# Altium schematic sheet wiced-ez-eval-core.SchDoc(wiced-ez-eval-core)
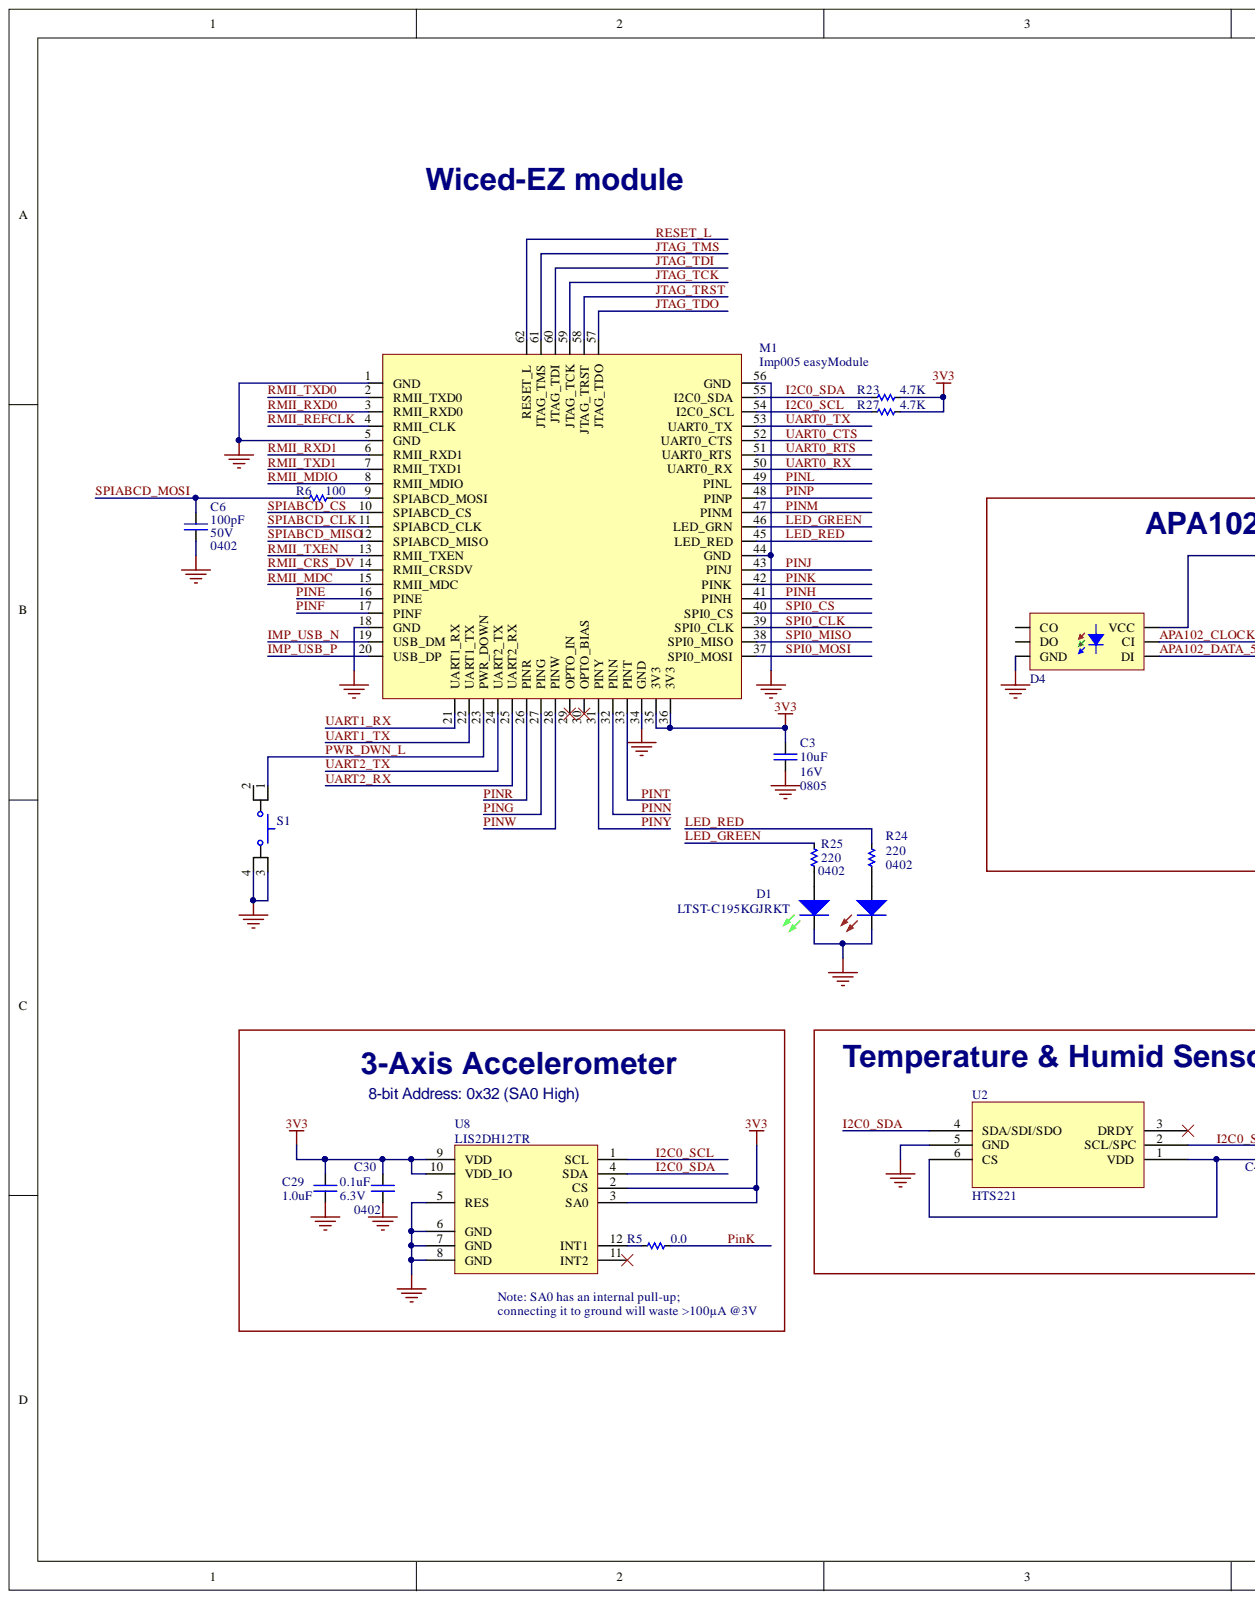
[diagram p0: wiced-ez-eval-core.SchDoc, left]
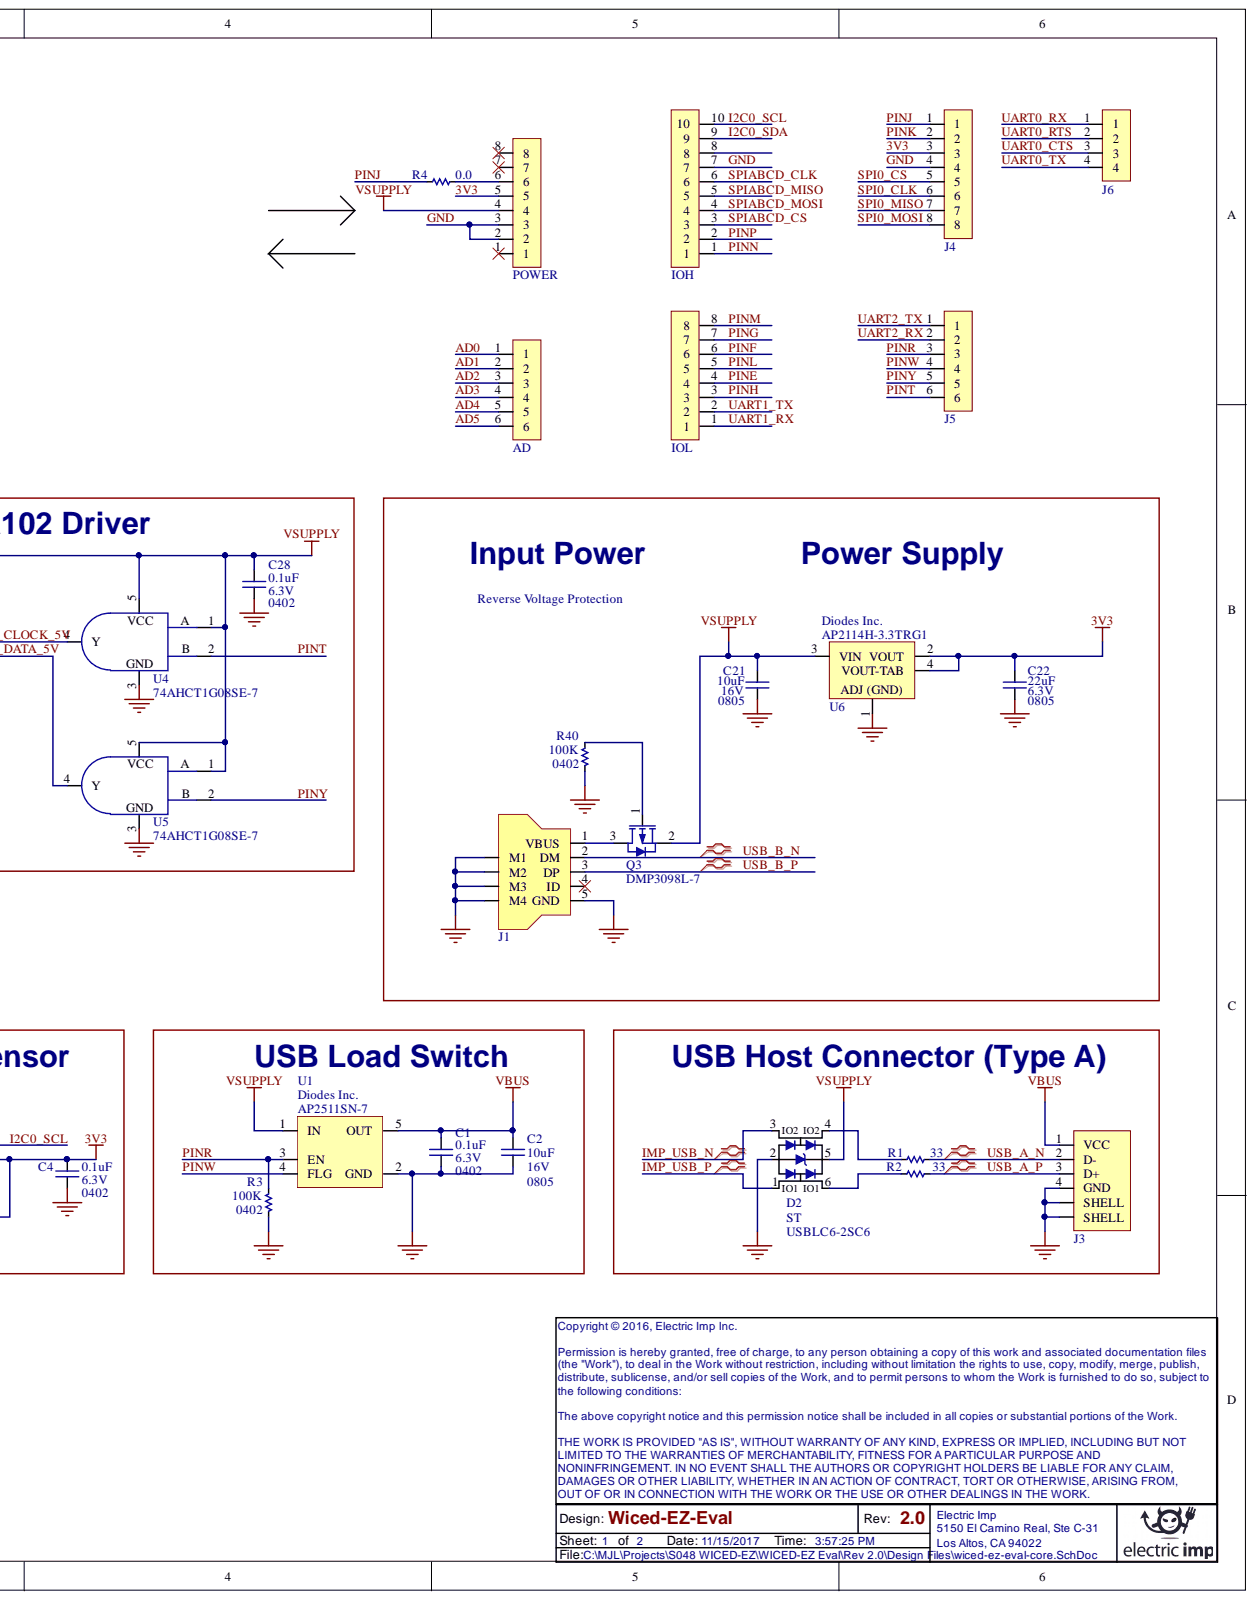
[diagram p1: wiced-ez-eval-core.SchDoc, right]

## Parts
AD  Header  [SKT254P1X6-N]  (value not drawn)
C1  value 0.1uF  Voltage: 6.3V  Package: 0402  [CAPC1005X07N]  (hidden comment: Ceramic Capacitor)
C2  value 10uF  Voltage: 16V  Package: 0805  [CAPC2012X14N]  (hidden comment: Ceramic Capacitor)
C3  value 10uF  Voltage: 16V  Package: 0805  [CAPC2012X14N]  (hidden comment: Ceramic Capacitor)
C4  value 0.1uF  Voltage: 6.3V  Package: 0402  [CAPC1005X07N]  (hidden comment: Ceramic Capacitor)
C6  value 100pF  Voltage: 50V  Package: 0402  [CAPC1005X07N]  (hidden comment: Ceramic Capacitor)
C21  value 10uF  Voltage: 16V  Package: 0805  [CAPC2012X14N]  (hidden comment: Ceramic Capacitor)
C22  value 22uF  Voltage: 6.3V  Package: 0805  [CAPC2012X14N]  (hidden comment: Ceramic Capacitor)
C28  value 0.1uF  Voltage: 6.3V  Package: 0402  [CAPC1005X07N]  (hidden comment: Ceramic Capacitor)
C29  value 1.0uF  [CAPC1005X07N]  (hidden comment: Ceramic Capacitor)
C30  value 0.1uF  Voltage: 6.3V  Package: 0402  [CAPC1005X07N]  (hidden comment: Ceramic Capacitor)
D1  Manufacturer Part Number: LTST-C195KGJRKT  [LED1616X07N-REDGREEN]  (hidden comment: LED Red and Green)
D2  Manufacturer Part Number: USBLC6-2SC6  Manufacturer: ST  [SOT95P300X130-6N]  (hidden comment: USB ESD Array)
D4  APA102C  [APA102C]
IOH  Header  [SKT254P1X10-N]  (value not drawn)
IOL  Header  [SKT254P1X8-N]  (value not drawn)
J1  USB Mini/Micro-B  [DX4R105JJCR1800]  (value not drawn)
J3  USB A Socket  [MOL482040001]  (value not drawn)
J4  Header  [SKT254P1X8-N]  (value not drawn)  (no BOM)
J5  Header  [SKT254P1X6-N]  (value not drawn)  (no BOM)
J6  Header  [HDR254P1X4-N]  (value not drawn)  (no BOM)
M1  Imp005 easyModule  [IMP005_EASYMODULE]
POWER  Header  [SKT254P1X8-N]  (value not drawn)
Q3  Manufacturer Part Number: DMP3098L-7  [SOT95P300X130-3N]  (hidden comment: PFET)
R1  value 33  [RESC1005X04N]  (hidden comment: Resistor)
R2  value 33  [RESC1005X04N]  (hidden comment: Resistor)
R3  value 100K  Package: 0402  [RESC1005X04N]  (hidden comment: Resistor)
R4  value 0.0  [RESC1005X04N]  (hidden comment: Resistor)
R5  value 0.0  [RESC1005X04N]  (hidden comment: Resistor)
R6  value 100  [RESC1005X04N]  (hidden comment: Resistor)
R23  value 4.7K  [RESC1005X04N]  (hidden comment: Resistor)
R24  value 220  [RESC1005X04N]  (hidden comment: Resistor)
R25  value 220  Package: 0402  [RESC1005X04N]  (hidden comment: Resistor)
R27  value 4.7K  [RESC1005X04N]  (hidden comment: Resistor)
R40  value 100K  Package: 0402  [RESC1005X04N]  (hidden comment: Resistor)
S1  Push Button  [BTN470X350X210-4N]  (value not drawn)
U1  AP2511SN  Manufacturer Part Number: AP2511SN-7  Manufacturer: Diodes Inc.  [QFN65P201X201X63_HS-7N]
U2  HTS221  [HLGA_6L]
U4  Manufacturer Part Number: 74AHCT1G08SE-7  [SOT65P200X125-5N]  (hidden comment: AND)
U5  Manufacturer Part Number: 74AHCT1G08SE-7  [SOT65P200X125-5N]  (hidden comment: AND)
U6  Manufacturer Part Number: AP2114H-3.3TRG1  Manufacturer: Diodes Inc.  [SOT230P700X180-4N]  (hidden comment: AP1117)
U8  LIS2DH12TR  [LGA50P200X200X100-12N-ST]

## Nets
VBUS: C1.2 C2.2 J3.1(VCC) U1.5(OUT)
VSUPPLY: C21.2 C28.2 D2.5 D4.4(VCC) POWER.4 Q3.2 U1.1(IN) U4.1 U4.5 U5.1 U5.5 U6.3(VIN)
3V3: C3.2 C4.2 C22.2 C29.2 C30.2 J4.3 M1.35(3V3) M1.36(3V3) POWER.5 R23.2 R27.2 U2.1(VDD) U2.6(CS) U6.2(VOUT) U6.4(VOUT-TAB) U8.2(CS) U8.3(SA0) U8.9(VDD) U8.10(VDD_IO)
AD0: AD.1
AD1: AD.2
AD2: AD.3
AD3: AD.4
AD4: AD.5
AD5: AD.6
APA102_CLOCK_5V: D4.2(CI) U4.4(Y)
APA102_DATA_5V: D4.1(DI) U5.4(Y)
GND: C1.1 C2.1 C3.1 C4.1 C6.1 C21.1 C22.1 C28.1 C29.1 C30.1 D1.KG D1.KR D2.2 D4.3(GND) IOH.7 J1.5(GND) J1.M1 J1.M2 J1.M3 J1.M4 J3.4(GND) J3.5(SHELL) J3.6(SHELL) J4.4 M1.1(GND) M1.5(GND) M1.18(GND) M1.34(GND) M1.44(GND) M1.56(GND) POWER.2 POWER.3 R3.2 R40.2 S1.3 S1.4 U1.2(GND) U2.5(GND) U4.3 U5.3 U6.1 U8.5(RES) U8.6(GND) U8.7(GND) U8.8(GND)
I2C0_SCL: IOH.10 M1.54(I2C0_SCL) R27.1 U2.2(SCL/SPC) U8.1(SCL)
I2C0_SDA: IOH.9 M1.55(I2C0_SDA) R23.1 U2.4(SDA/SDI/SDO) U8.4(SDA)
IMP_USB_N: D2.3(IO2) M1.19(USB_DM)
IMP_USB_P: D2.1(IO1) M1.20(USB_DP)
JTAG_TCK: M1.59(JTAG_TCK)
JTAG_TDI: M1.60(JTAG_TDI)
JTAG_TDO: M1.57(JTAG_TDO)
JTAG_TMS: M1.61(JTAG_TMS)
JTAG_TRST: M1.58(JTAG_TRST)
LED_GREEN: M1.46(LED_GRN) R25.1
LED_RED: M1.45(LED_RED) R24.1
PINE: IOL.4 M1.16(PINE)
PINF: IOL.6 M1.17(PINF)
PING: IOL.7 M1.27(PING)
PINH: IOL.3 M1.41(PINH)
PINJ: J4.1 M1.43(PINJ) R4.1
PinK: J4.2 M1.42(PINK) R5.2
PINL: IOL.5 M1.49(PINL)
PINM: IOL.8 M1.47(PINM)
PINN: IOH.1 M1.32(PINN)
PINP: IOH.2 M1.48(PINP)
PINR: J5.3 M1.26(PINR) R3.1 U1.3(EN)
PINT: J5.6 M1.33(PINT) U4.2(B)
PINW: J5.4 M1.28(PINW) U1.4(FLG)
PINY: J5.5 M1.31(PINY) U5.2(B)
PWR_DWN_L: M1.23(PWR_DOWN) S1.1
RESET_L: M1.62(RESET_L)
RMII_CRS_DV: M1.14(RMII_CRSDV)
RMII_MDC: M1.15(RMII_MDC)
RMII_MDIO: M1.8(RMII_MDIO)
RMII_REFCLK: M1.4(RMII_CLK)
RMII_RXD0: M1.3(RMII_RXD0)
RMII_RXD1: M1.6(RMII_RXD1)
RMII_TXD0: M1.2(RMII_TXD0)
RMII_TXD1: M1.7(RMII_TXD1)
RMII_TXEN: M1.13(RMII_TXEN)
SPI0_CLK: J4.6 M1.39(SPI0_CLK)
SPI0_CS: J4.5 M1.40(SPI0_CS)
SPI0_MISO: J4.7 M1.38(SPI0_MISO)
SPI0_MOSI: J4.8 M1.37(SPI0_MOSI)
SPIABCD_CLK: IOH.6 M1.11(SPIABCD_CLK)
SPIABCD_CS: IOH.3 M1.10(SPIABCD_CS)
SPIABCD_MISO: IOH.5 M1.12(SPIABCD_MISO)
SPIABCD_MOSI: C6.2 IOH.4 R6.1
UART0_CTS: J6.3 M1.52(UART0_CTS)
UART0_RTS: J6.2 M1.51(UART0_RTS)
UART0_RX: J6.1 M1.50(UART0_RX)
UART0_TX: J6.4 M1.53(UART0_TX)
UART1_RX: IOL.1 M1.21(UART1_RX)
UART1_TX: IOL.2 M1.22(UART1_TX)
UART2_RX: J5.2 M1.25(UART2_RX)
UART2_TX: J5.1 M1.24(UART2_TX)
USB_A_N: J3.2(D-) R1.2
USB_A_P: J3.3(D+) R2.2
USB_B_N: J1.2(DM)
USB_B_P: J1.3(DP)
NetD1_AG: D1.AG R25.2
NetD1_AR: D1.AR R24.2
NetD2_4: D2.4(IO2) R1.1
NetD2_6: D2.6(IO1) R2.1
NetJ1_1: J1.1(VBUS) Q3.3
NetM1_9: M1.9(SPIABCD_MOSI) R6.2
NetPOWER_6: POWER.6 R4.2
NetQ3_1: Q3.1 R40.1
NetR5_1: R5.1 U8.12(INT1)

Not connected: D4 5(CO) 6(DO); IOH 8; J1 4(ID); M1 29(OPTO_IN) 30(OPTO_BIAS); POWER 1 7 8; S1 2; U2 3(DRDY); U8 11(INT2)

## Library data of the parts
AD J5 = HDR00019: SKT, 1x6 Pin, 2.54mm Pitch, Gold Flash, Vertical, TH; Manufacturer Part Number: 75915-306LF; Manufacturer: FCI; Number of Positions Loaded: 6; Gender: Female; Database Table Name: headers$; Connector Type: Socket; Supplier Price 1: 0.4942; Supplier Part Number 1: 609-3558-ND; Supplier 1: Digi-Key; Pitch: 2.54mm; Part Number: HDR00019; Orientation: Vertical; Number of Rows: 1; Number of Columns: 6; Mounting Type: Through Hole; Library Name: connectors.DbLib; Contact Finish: Gold Flash
  AD: Component Kind: Standard
C1 C4 C28 C30 = CAPC00002: CAP,X5R,0.1uF,10%,6.3V, 0402,#; Manufacturer Part Number: C1005X5R0J104K; Manufacturer: TDK; Database Table Name: capacitor$; Tolerance: 10%; Supplier Price 2: 0.007; Supplier Price 1: 0.0066; Supplier Part Number 2: 81-GRM155R60J104KA1D; Supplier Part Number 1: 445-1266-1-ND; Supplier 2: Mouser; Supplier 1: Digi-Key; Part Number: CAPC00002; Manufacturer Part Number 2: GRM155R60J104KA01D; Manufacturer 2: Murata; Library Name: passives.DbLib; Dielectric: X5R; Component Kind: Standard
C2 C3 C21 = CAPC00037: CAP,X5R,10uF,10%,16V,0805,#; Manufacturer Part Number: CL21A106KOQNNNE; Manufacturer: Samsung; Database Table Name: capacitor$; Tolerance: 10%; Supplier Price 1: 0.0385; Supplier Part Number 2: 587-1295-1-ND; Supplier Part Number 1: 1276-1096-1-ND; Supplier 2: Digi-Key; Supplier 1: Digi-Key; Stock Quantity: 1000; Stock Date: 3/12/2013; Part Number: CAPC00037; Manufacturer Part Number 2: EMK212BJ106KG-T; Manufacturer 2: Taiyo Yuden; Library Name: passives.DbLib; Dielectric: X5R; Component Kind: Standard
  C2 C3: Supplier Price 2: 0.05355
  C21: Supplier Price 2: 0.0536
C6 = CAPC00043: CAP,C0G,100pF,5%,50V,0402; Manufacturer Part Number: CC0402JRNPO9BN101; Manufacturer: Yageo; Database Table Name: capacitor$; Tolerance: 5%; Supplier Price 2: 0.0032; Supplier Price 1: 0.0032; Supplier Part Number 2: 1276-1025-1-ND; Supplier Part Number 1: 311-1024-1-ND; Supplier 2: Digi-Key; Supplier 1: Digi-Key; Part Number: CAPC00043; Manufacturer Part Number 2: CL05C101JB5NNNC; Manufacturer 2: Samsung; Library Name: passives.DbLib; Dielectric: C0G; Component Kind: Standard
C22 = CAPC00039: CAP,X5R,22uF,10%,6.3V,0805,#; Manufacturer Part Number: C2012X5R0J226K/1.25; Manufacturer: TDK; Database Table Name: capacitor$; Tolerance: 10%; Supplier Price 2: 0.2288; Supplier Price 1: 0.225; Supplier Part Number 2: 587-1471-2-ND; Supplier Part Number 1: 445-7679-1-ND; Supplier 2: Digi-Key; Supplier 1: Digi-Key; Part Number: CAPC00039; Manufacturer Part Number 2: JMK212BJ226KG-T; Manufacturer 2: Taiyo Yuden; Library Name: passives.DbLib; Dielectric: X5R; Component Kind: Standard
C29 = CAPC00005: CAP,X5R,1.0uF,10%,6.3V, 0402,#; Manufacturer Part Number: GRM155R60J105KE19D; Manufacturer: Murata; Database Table Name: capacitor$; Tolerance: 10%; Supplier Price 2: 0.009; Supplier Price 1: 0.0087; Supplier Part Number 2: 1276-1010-1-ND; Supplier Part Number 1: 490-1320-1-ND; Supplier 2: Digi-Key; Supplier 1: Digi-Key; Stock Quantity: 1000; Stock Date: 3/12/2013; Part Number: CAPC00005; Manufacturer Part Number 2: CL05A105KQ5NNNC; Manufacturer 2: Samsung; Library Name: passives.DbLib; Dielectric: X5R; Component Kind: Standard
D1 = LED00012: LED, Red and Green, 2/2V, 631/571nm, 25/35mcd, SMT; Manufacturer: Liteon; Wavelength - Peak: 639nm, 574nm; Wavelength - Dominant: 631nm, 571nm; Voltage - Forward (Vf) Typ: 2V, 2V; Viewing Angle: 130°; Millicandela Rating (min): 25mcd, 35mcd; Lens Type: Clear; Database Table Name: LED$; Current - Test: 20mA; Color: Red/Green; Supplier Price 1: 0.1206; Supplier Part Number 1: 160-1452-1-ND; Supplier 1: Digi-Key; Stock Quantity: 31; Stock Date: 8/22/2014; Part Number: LED00012; Package: SMT; Library Name: opto.DbLib; ComponentLink1URL: http://media.digikey.com/pdf/Data%20Sheets/Lite-On%20PDFs/LTST-C195KGJRKT.pdf; Component Kind: Standard
D2 = TVS00007: TVS Array, USB Flowthough, Powerline Clamp, SOT23-6; Varistor Voltage: 6V; Max VDC: 5.25V; Database Table Name: TVS$; Supplier Price 1: 0.15725; Supplier Part Number 1: 497-5235-1-ND; Supplier 1: Digi-Key; Part Number: TVS00007; Library Name: discretes.DbLib; Imax: -; ComponentLink1URL: http://www.digikey.com/product-detail/en/USBLC6-2SC6/497-5235-1-ND/1121688; Component Kind: Standard
D4 = LED00058: LED, RGB, w/ Integrated Driver, 5.0V, SMT; Manufacturer: Shiji Lighting; Voltage - Forward (Vf) Typ: 2.8V; Viewing Angle: 160º; Millicandela Rating (min): 500mcd, 370mcd, 120mcd; Lens Type: Clear; Database Table Name: LED$; Current - Test: 20mA; Color: Red/Green/Blue; Wavelength - Peak: -; Wavelength - Dominant: -; Supplier Price 1: 3.6; Supplier Part Number 1: 2343; Supplier 1: Adafruit; Part Number: LED00058; Package: SMT; Orientation: Top-View; Manufacturer Part Number: APA102C; Library Name: opto.DbLib; ComponentLink1URL: http://www.adafruit.com/products/2343; Component Kind: Standard
IOH = HDR00065: SKT, 1x10 Pin, 2.54mm Pitch, Gold Flash, Vertical, TH; Manufacturer Part Number: 75915-310LF; Manufacturer: FCI; Number of Positions Loaded: 10; Gender: Female; Database Table Name: headers$; Connector Type: Socket; Supplier Price 1: 0.7778; Supplier Part Number 1: 609-3550-ND; Supplier 1: Digi-Key; Pitch: 2.54mm; Part Number: HDR00065; Orientation: Vertical; Number of Rows: 1; Number of Columns: 10; Mounting Type: Through Hole; Library Name: connectors.DbLib; Contact Finish: Gold Flash; Component Kind: Standard
IOL J4 POWER = HDR00018: SKT, 1x8 Pin, 2.54mm Pitch, Gold Flash, Vertical, TH; Manufacturer Part Number: 75915-308LF; Manufacturer: FCI; Number of Positions Loaded: 8; Gender: Female; Database Table Name: headers$; Connector Type: Socket; Supplier Price 1: 0.6394; Supplier Part Number 1: 609-3559-ND; Supplier 1: Digi-Key; Pitch: 2.54mm; Part Number: HDR00018; Orientation: Vertical; Number of Rows: 1; Number of Columns: 8; Mounting Type: Through Hole; Library Name: connectors.DbLib; Contact Finish: Gold Flash
  IOL POWER: Component Kind: Standard
J1 = USB00004: Conn, USB, RCPT, Type B, Micro, Bottom Entry, Surface Mount; Manufacturer: JAE Electronics; Database Table Name: USB$; Supplier Price 1: 0.78046; Supplier Part Number 1: 670-2676-1-ND; Supplier 1: Digi-Key; Part Number: USB00004; Manufacturer Part Number: DX4R105JJCR1800; Library Name: connectors.DbLib; ComponentLink1URL: http://www.digikey.com/product-detail/en/DX4R105JJCR1800/670-2676-1-ND/3903236; Component Kind: Standard
J3 = USB00006: Conn, USB, RCPT, Type A, RT Angle, Vertical, TH; Manufacturer Part Number: 0482040001; Manufacturer: Molex; Database Table Name: USB$; Supplier Price 2: 0.73808; Supplier Price 1: 0.692; Supplier Part Number 2: WM17532-ND; Supplier Part Number 1: 538-48204-0001; Supplier 2: Digi-Key; Supplier 1: Mouser; Part Number: USB00006; Library Name: connectors.DbLib; ComponentLink1URL: http://www.mouser.com/ProductDetail/Molex/48204-0001/?qs=sGAEpiMZZMulM8LPOQ%252bykxKOk52KZ3dl2EXLb7Ox2AY%3d; Component Kind: Standard
J6 = HDR00004: HDR, 1x4 Pin, 2.54mm Pitch, Gold Flash, Vertical, TH; Manufacturer Part Number: 68000-404HLF; Manufacturer: FCI; Number of Positions Loaded: 4; Gender: Male; Database Table Name: headers$; Connector Type: Unshrouded; Supplier Price 2: 0.0093; Supplier Price 1: 0.1071; Supplier Part Number 2: 00804; Supplier Part Number 1: 609-3262-ND; Supplier 2: 4UCON; Supplier 1: Digi-Key; Pitch: 2.54mm; Part Number: HDR00004; Orientation: Vertical; Number of Rows: 1; Number of Columns: 4; Mounting Type: Through Hole; Manufacturer Part Number 2: 00804; Manufacturer 2: 4UCON; Library Name: connectors.DbLib; Contact Finish: Gold Flash
M1 = MOD00029: Module, Imp005 with antenna, .05" pitch, 56+6pin, SMD; Manufacturer: Electric Imp; Database Table Name: misc$; Part Number: MOD00029; Library Name: modules.DbLib; Component Kind: Standard
Q3 = PFET00004: PFET, 30V, 3.8A, 20Vgs, SOT23; Manufacturer: Diodes Inc; Vgs(th) (Max) @ Id: 1.8V @ 250uA; Rds On (Max) @ Id, Vgs: 56 mOhm @ 3.8A, 10V; Power - Max: 1.08W; Database Table Name: PFET$; Supplier Price 1: 0.111; Supplier Part Number 1: DMP3098LDITR-ND; Supplier 1: Digi-key; Stock Quantity: 213; Stock Date: 3/5/2013; Simulation: PMOS; Part Number: PFET00004; Library Name: discretes.DbLib; Drain to Source Voltage (Vdss): 30V; Current - Continuous Drain (Id) @ 25° C: 3.8A; ComponentLink1URL: http://www.digikey.com/product-detail/en/DMP3098L-7/DMP3098LDICT-ND/1964773; Component Kind: Standard
R1 R2 = RES00057: RES,33 Ohm,1%,0.063W,0402; Manufacturer Part Number: MCR01MRTF33R0; Manufacturer: Rohm; Database Table Name: resistor$; Tolerance: 1%; Supplier Price 2: 0.00149; Supplier Price 1: 0.00132; Supplier Part Number 2: 311-33.0LRTR-ND; Supplier Part Number 1: RHM33.0CDTR-ND; Supplier 2: Digi-Key; Supplier 1: Digi-Key; Power: 0.063W; Part Number: RES00057; Package: 0402; Manufacturer Part Number 2: RC0402FR-0733RL; Manufacturer 2: Yageo; Library Name: passives.DbLib; Component Kind: Standard
R3 R40 = RES00001: RES,100K,5%,0.063W,0402,#; Manufacturer Part Number: RC1005J104CS; Manufacturer: Samsung; Database Table Name: resistor$; Supplier Price 2: 0.00233; Supplier Price 1: 0.0023; Supplier Part Number 2: HM100KCECT-ND; Supplier Part Number 1: 1276-4424-1-ND; Supplier 2: Digi-Key; Supplier 1: Digi-Key; Stock Quantity: 2100; Stock Date: 4/5/2013; Power: 0.063W; Part Number: RES00001; Manufacturer Part Number 2: MCR01MRTJ104; Manufacturer 2: ROHM; Library Name: passives.DbLib; Component Kind: Standard
R4 = RES00019: RES,0 Ohm,0.063W,0402; Manufacturer Part Number: RC0402JR-070RL; Manufacturer: Yageo; Tolerance: 0%; Database Table Name: resistor$; Supplier Price 2: 0.00352; Supplier Price 1: 0.00237; Supplier Part Number 2: RHM0.0CECT-ND; Supplier Part Number 1: 311-0.0JRCT-ND; Supplier 2: Digi-Key; Supplier 1: Digi-Key; Power: 0.063W; Part Number: RES00019; Package: 0402; Manufacturer Part Number 2: MCR01MRTJ000; Manufacturer 2: ROHM; Library Name: passives.DbLib; Component Kind: Standard
R5 = RES00019: RES,0 Ohm,0.063W,0402; Manufacturer Part Number: RC0402JR-070RL; Manufacturer: Yageo; Tolerance: 0%; Database Table Name: resistor$; BOMOPTION: DNS; Supplier Price 2: 0.00352; Supplier Price 1: 0.00237; Supplier Part Number 2: RHM0.0CECT-ND; Supplier Part Number 1: 311-0.0JRCT-ND; Supplier 2: Digi-Key; Supplier 1: Digi-Key; Power: 0.063W; Part Number: RES00019; Package: 0402; Manufacturer Part Number 2: MCR01MRTJ000; Manufacturer 2: ROHM; Library Name: passives.DbLib; Component Kind: Standard
R6 = RES00024: RES,100 Ohm,1%,0.063W,0402,#; Manufacturer Part Number: RC0402FR-07100RL; Manufacturer: Yageo; Database Table Name: resistor$; Tolerance: 1%; Supplier Price 2: 0.0048; Supplier Price 1: 0.0027; Supplier Part Number 2: RHM100CDCT-ND; Supplier Part Number 1: 311-100LRCT-ND; Supplier 2: Digi-Key; Supplier 1: Digi-Key; Stock Quantity: 1000; Stock Date: 4/5/2013; Power: 0.063W; Part Number: RES00024; Package: 0402; Manufacturer Part Number 2: MCR01MRTF1000; Manufacturer 2: ROHM; Library Name: passives.DbLib; Component Kind: Standard
R23 R27 = RES00005: RES,4.7K,5%,0.063W,0402,#; Manufacturer Part Number: RC0402JR-074K7L; Manufacturer: Yageo; Database Table Name: resistor$; Tolerance: 5%; Supplier Price 2: 0.00352; Supplier Price 1: 0.00237; Supplier Part Number 2: RHM4.7KCECT-ND; Supplier Part Number 1: 311-4.7KJRCT-ND; Supplier 2: Digi-Key; Supplier 1: Digi-Key; Stock Quantity: 100; Stock Date: 4/19/2013; Power: 0.063W; Part Number: RES00005; Package: 0402; Manufacturer Part Number 2: MCR01MRTJ472; Manufacturer 2: ROHM; Library Name: passives.DbLib; Component Kind: Standard
R24 R25 = RES00011: RES,220 Ohm,5%,0.063W,0402; Manufacturer Part Number: RC0402JR-07220RL; Manufacturer: Yageo; Database Table Name: resistor$; Tolerance: 5%; Supplier Price 2: 0.0048; Supplier Price 1: 0.00237; Supplier Part Number 2: P220JCT-ND; Supplier Part Number 1: 311-220JRCT-ND; Supplier 2: Digi-Key; Supplier 1: Digi-Key; Power: 0.063W; Part Number: RES00011; Manufacturer Part Number 2: ERJ-2GEJ221X; Manufacturer 2: Panasonic; Library Name: passives.DbLib; Component Kind: Standard
  R24: Package: 0402
S1 = BTN00002: SPST- NO Momentary Button, 160g Force; Manufacturer Part Number: EVQ-P2202M; Manufacturer: Panasonic; Database Table Name: button$; Supplier Price 1: 0.45619; Supplier Part Number 1: P12298SCT-ND; Supplier 1: Digi-Key; Part Number: BTN00002; Library Name: electromechanical.DbLib; ComponentLink1URL: http://www.digikey.com/product-detail/en/EVQ-P2202M/P12298SCT-ND/593598; Component Kind: Standard
U1 = IC00146: IC, USB Load Switch, Active-High Enable, 70mΩ RDS_on, 3.7A Limit, UDFN2020; Database Table Name: misc$; Supplier Price 1: 0.16188; Supplier Part Number 1: AP2511SN-7DICT-ND; Supplier 1: Digi-Key; Part Number: IC00146; Library Name: ic.DbLib; ComponentLink1URL: http://www.digikey.com/product-detail/en/AP2511SN-7/AP2511SN-7DICT-ND/4251586; Component Kind: Standard
U2 = IC00208: IC, Sensor, Temp+Humidity, ST HTS221, +/-4.5% RH; Manufacturer Part Number: HTS221TR; Manufacturer: ST Micro; Database Table Name: misc$; Supplier Price 1: 1.7136; Supplier Part Number 1: 497-15382-1-ND; Supplier 1: Digi-Key; Part Number: IC00208; Library Name: ic.DbLib; ComponentLink1URL: http://www.digikey.com/product-detail/en/stmicroelectronics/HTS221TR/497-15382-1-ND/5180533; Component Kind: Standard
U4 U5 = IC00116: IC, Logic, 2-Input AND, Level Translating, SOT-353; Manufacturer: Diodes Inc.; Database Table Name: misc$; Supplier Price 2: 0.07022; Supplier Price 1: 0.04886; Supplier Part Number 2: 568-4463-1-ND; Supplier Part Number 1: 74AHCT1G08SE-7DICT-ND; Supplier 2: Digi-Key; Supplier 1: Digi-Key; Stock Quantity: 0; Part Number: IC00116; Manufacturer Part Number 2: 74AHCT1G08GW,125; Manufacturer 2: NXP; Library Name: ic.DbLib; ComponentLink1URL: http://www.digikey.com/product-detail/en/74AHCT1G08SE-7/74AHCT1G08SE-7DICT-ND/2712017; Component Kind: Standard
U6 = IC00159: IC, LDO, Fixed 3.3V, 1A, 6VIN Max, Ceramic Stable, SOT-223; Database Table Name: misc$; Supplier Price 1: 0.1305; Supplier Part Number 1: AP2114H-3.3TRG1DICT-ND; Supplier 1: Digi-Key; Part Number: IC00159; Library Name: ic.DbLib; ComponentLink1URL: http://www.digikey.com/product-detail/en/AP2114H-3.3TRG1/AP2114H-3.3TRG1DICT-ND/4505142; Component Kind: Standard
U8 = IC00219: IC, Accelerometer, 3-Axis, 12-bit, LGA12; Manufacturer: ST Micro; Database Table Name: misc$; Supplier Price 1: 1.11; Supplier Part Number 1: 497-14851-1-ND; Supplier 1: Digi-Key; Part Number: IC00219; Manufacturer Part Number: LIS2DH12TR; Library Name: ic.DbLib; ComponentLink1URL: http://www.st.com/web/en/resource/technical/document/datasheet/DM00091513.pdf; Component Kind: Standard
